# Altium schematic sheet RX Chain.SchDoc("RX Chain")
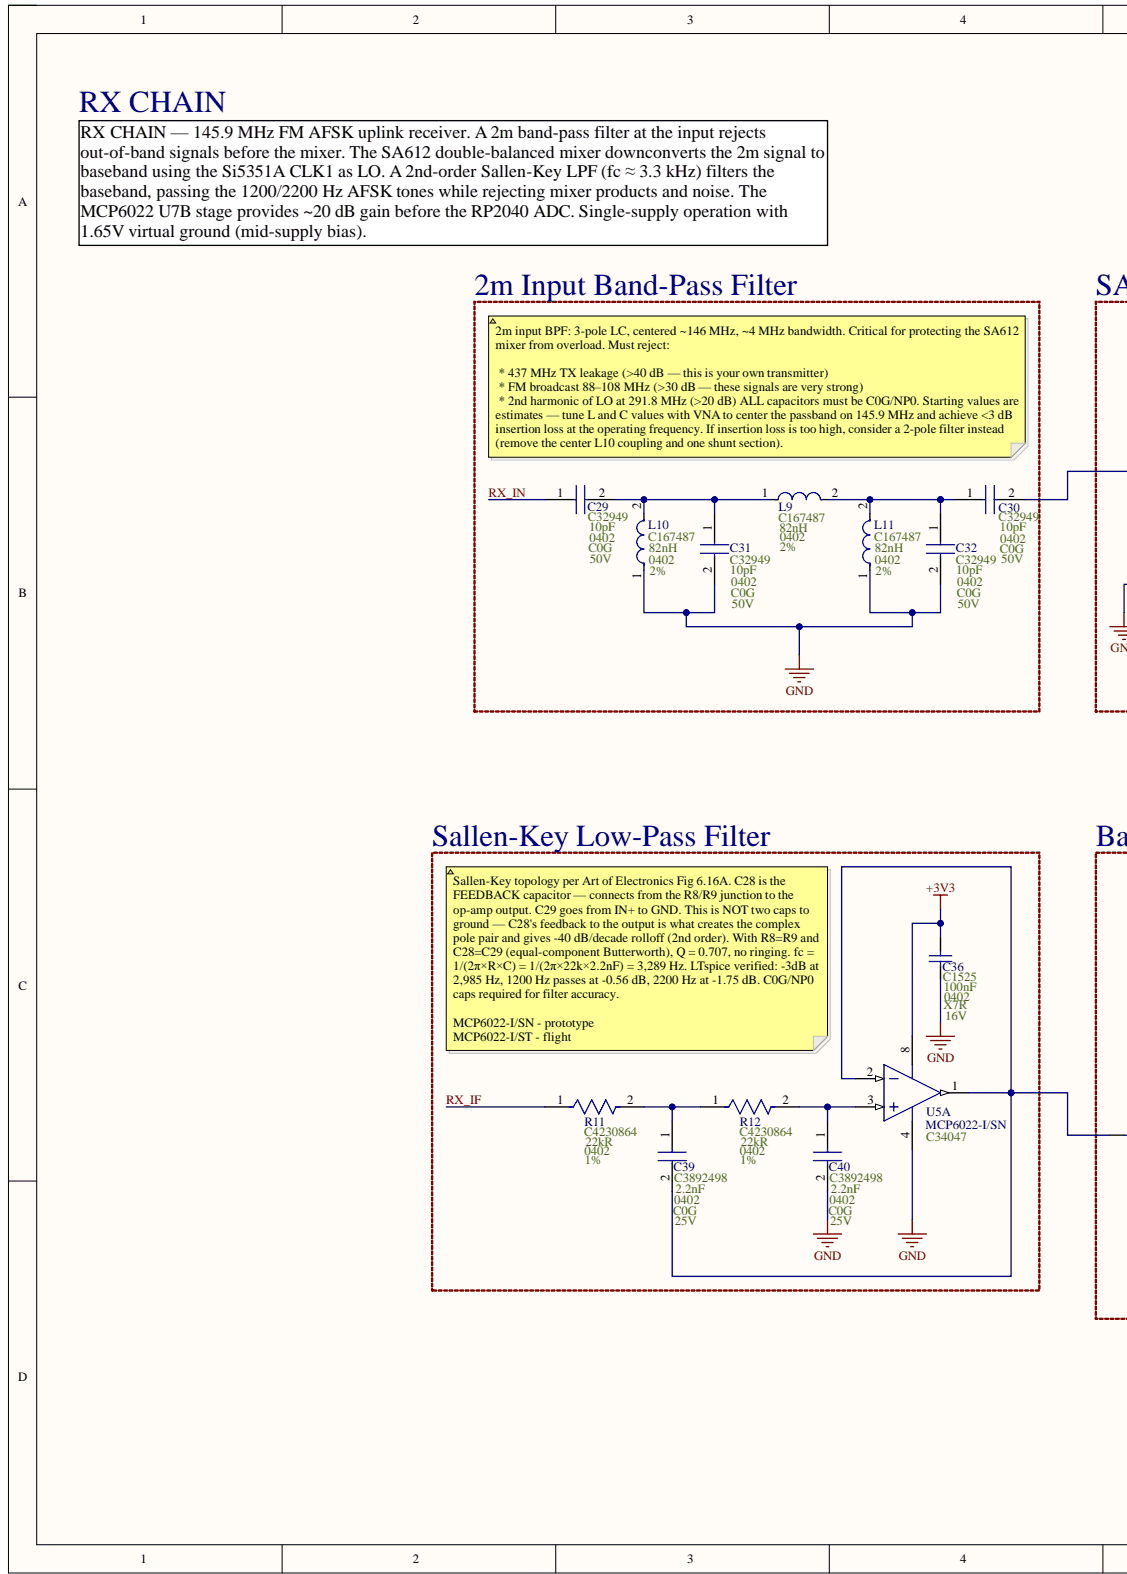
[diagram p0: RX Chain.SchDoc, left]
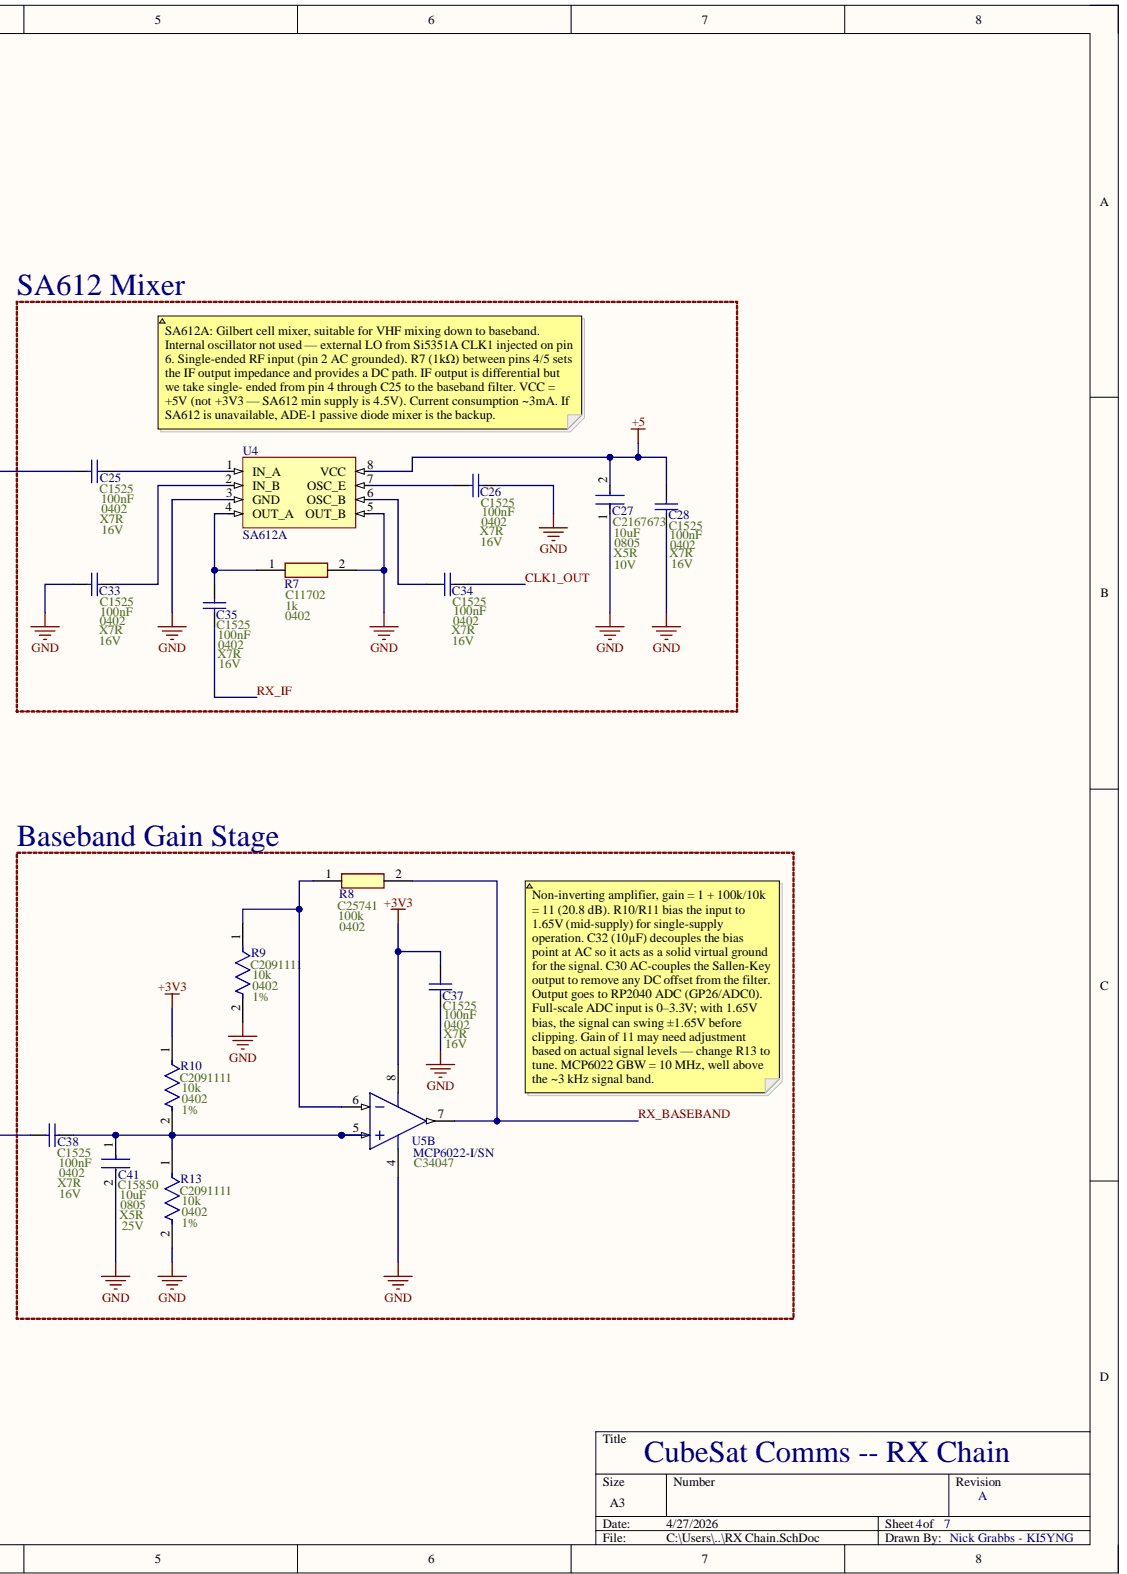
[diagram p1: RX Chain.SchDoc, right]

## Parts
C25  Capacitance: 100nF  Case Size/Dimension: 0402  Dielectric: X7R  LCSC Part #: C1525  [CAPC1050X55X23ML05T13]  (hidden comment: CL05B104KO5NNNC)
C26  Capacitance: 100nF  Case Size/Dimension: 0402  Dielectric: X7R  LCSC Part #: C1525  [CAPC1050X55X23ML05T13]  (hidden comment: CL05B104KO5NNNC)
C27  value 10uF  Capacitance: 10uF  LCSC Part #: C2167673  Package: 0805  [FP-C0805C-DG-MFG]  (hidden comment: C0805C106K8PACTU)
C28  Capacitance: 100nF  Case Size/Dimension: 0402  Dielectric: X7R  LCSC Part #: C1525  Rated Voltage: 16V  [CAPC1050X55X23ML05T13]  (hidden comment: CL05B104KO5NNNC)
C29  value 10pF  Case: 0402  Dielectric: C0G  LCSC Part #: C32949  [CAPC1005X55N]  (hidden comment: CL05C100JB5NNNC)
C30  value 10pF  Case: 0402  Dielectric: C0G  LCSC Part #: C32949  [CAPC1005X55N]  (hidden comment: CL05C100JB5NNNC)
C31  value 10pF  Case: 0402  Dielectric: C0G  LCSC Part #: C32949  Voltage: 50V  [CAPC1005X55N]  (hidden comment: CL05C100JB5NNNC)
C32  value 10pF  Case: 0402  Dielectric: C0G  LCSC Part #: C32949  Voltage: 50V  [CAPC1005X55N]  (hidden comment: CL05C100JB5NNNC)
C33  Capacitance: 100nF  Case Size/Dimension: 0402  Dielectric: X7R  LCSC Part #: C1525  Rated Voltage: 16V  [CAPC1050X55X23ML05T13]  (hidden comment: CL05B104KO5NNNC)
C34  Capacitance: 100nF  Case Size/Dimension: 0402  Dielectric: X7R  LCSC Part #: C1525  Rated Voltage: 16V  [CAPC1050X55X23ML05T13]  (hidden comment: CL05B104KO5NNNC)
C35  Capacitance: 100nF  Case Size/Dimension: 0402  Dielectric: X7R  LCSC Part #: C1525  Rated Voltage: 16V  [CAPC1050X55X23ML05T13]  (hidden comment: CL05B104KO5NNNC)
C36  Capacitance: 100nF  Case Size/Dimension: 0402  Dielectric: X7R  LCSC Part #: C1525  [CAPC1050X55X23ML05T13]  (hidden comment: CL05B104KO5NNNC)
C37  Capacitance: 100nF  Case Size/Dimension: 0402  Dielectric: X7R  LCSC Part #: C1525  Rated Voltage: 16V  [CAPC1050X55X23ML05T13]  (hidden comment: CL05B104KO5NNNC)
C38  Capacitance: 100nF  Case Size/Dimension: 0402  LCSC Part #: C1525  [CAPC1050X55X23ML05T13]  (hidden comment: CL05B104KO5NNNC)
C39  value 2.2nF  Case: 0402  Dielectric: C0G  LCSC Part #: C3892498  Voltage: 25V  [C0402]  (hidden comment: C0402C222F3GECAUTO)
C40  value 2.2nF  Case: 0402  Dielectric: C0G  LCSC Part #: C3892498  Voltage: 25V  [C0402]  (hidden comment: C0402C222F3GECAUTO)
C41  Capacitance: 10uF  Case Code (Imperial): 0805  LCSC Part #: C15850  [FP-CL21-IPC_A]  (hidden comment: CL21A106KAYNNNE)
L9  Case Code (Imperial): 0402  Inductance: 82nH  LCSC Part #: C167487  [FP-LQW15AN_00-W0_5-0_1-MFG]  (hidden comment: LQW15AN82NG00D)
L10  Case Code (Imperial): 0402  Inductance: 82nH  Inductance Tolerance: 2%  LCSC Part #: C167487  [FP-LQW15AN_00-W0_5-0_1-MFG]  (hidden comment: LQW15AN82NG00D)
L11  Case Code (Imperial): 0402  Inductance: 82nH  Inductance Tolerance: 2%  LCSC Part #: C167487  [FP-LQW15AN_00-W0_5-0_1-MFG]  (hidden comment: LQW15AN82NG00D)
R7  value 1k  Case: 0402  LCSC Part #: C11702  [RESC1005X40N]  (hidden comment: 0402WGF1001TCE)
R8  value 100k  Case: 0402  LCSC Part #: C25741  [RESC1005X40N]  (hidden comment: 0402WGF1003TCE)
R9  value 10k  Case: 0402  LCSC Part #: C2091111  Tolerance: 1%  [FP-CR0402-MFG]  (hidden comment: CR0402AFX-1002GAS)
R10  value 10k  Case: 0402  LCSC Part #: C2091111  Tolerance: 1%  [FP-CR0402-MFG]  (hidden comment: CR0402AFX-1002GAS)
R11  Case/Package: 0402  LCSC Part #: C4230864  Resistance: 22kR  [FP-AF0402-IPC_C]  (hidden comment: AF0402FR-0722KL)
R12  Case/Package: 0402  LCSC Part #: C4230864  Resistance: 22kR  [FP-AF0402-IPC_C]  (hidden comment: AF0402FR-0722KL)
R13  value 10k  Case: 0402  LCSC Part #: C2091111  Tolerance: 1%  [FP-CR0402-MFG]  (hidden comment: CR0402AFX-1002GAS)
U4  SA612A
U5  MCP6022-I/SN  LCSC Part #: C34047  [SOIC-SN8_N]  (parts A,B)

## Nets
+3V3: C36.1 C37.1 R10.1 U5.8
+5: C27.2 C28.1 U4.8(VCC)
GND: C26.1 C27.1 C28.2 C31.2 C32.2 C33.2 C36.2 C37.2 C40.2 C41.2 L10.1 L11.1 R7.2 R9.2 R13.2 U4.3(GND) U4.5(OUT_B) U5.4
CLK1_OUT: C34.1
RX_BASEBAND: R8.2 U5.7
RX_IF: C35.2 R11.1
RX_IN: C29.1
NetC25_1: C25.1 U4.1(IN_A)
NetC25_2: C25.2 C30.2
NetC26_2: C26.2 U4.7(OSC_E)
NetC29_2: C29.2 C31.1 L9.1 L10.2
NetC30_1: C30.1 C32.1 L9.2 L11.2
NetC33_1: C33.1 U4.2(IN_B)
NetC34_2: C34.2 U4.6(OSC_B)
NetC35_1: C35.1 R7.1 U4.4(OUT_A)
NetC38_1: C38.1 C41.1 R10.2 R13.1 U5.5
NetC38_2: C38.2 C39.2 U5.1 U5.2
NetC39_1: C39.1 R11.2 R12.1
NetC40_1: C40.1 R12.2 U5.3
NetR8_1: R8.1 R9.1 U5.6

## Library data of the parts
C25 C26 C36 = CMP-2000-05439-1: Chip Capacitor, 100 nF, +/- 10%, 16 V, -55 to 125 degC, 0402 (1005 Metric), RoHS, Tape and Reel; Manufacturer: Samsung Electro-Mechanics; Rated Voltage: 16V; Component Kind: Standard; Datasheet URL: https://datasheet.ciiva.com/29198/458718-da-01-en-vs-kondensator-0402-29198423.pdf; Datasheet Version: Nov-10; Library Name: Altium Content Vault; Manufacturer URL: http://www.samsungsem.com/global/index.jsp; Tolerance (%): ±10%
C27 = CMP-2007-03243-2: CAP CER 10UF 10V X5R 0805; 3DS Part Number: 220-00001; Manufacturer: Kemet; Material: Ceramic; Temperature Coefficient: 15%; Tolerance: 10%; Automotive: No; Category: Cap; Component Kind: Standard; ComponentLink2Description: Datasheet; ComponentLink2URL: https://content.kemet.com/datasheets/KEM_C1006_X5R_SMD.pdf; Device Class L1: Passive Components; Device Class L2: Capacitors; Device Class L3: Ceramic Capacitors; Digikey Description: CAP CER 10UF 10V X5R 0805; Height: 1.4mm; Ipc Land Pattern Name: CAPC200125X125; Lead Free: Yes; Library Name: Altium Content Vault; Manufacturer Part Number: C0805C106K8PACTU; Mouser Description: CAP CER 10UF 10V X5R 0805; Octopart: https://octopart.com/c0805c106k8pactu-kemet-98697; RoHS: TRUE; Temperature: -55°C to +85°C; Temperature Characteristic: X5R; Temperature Range High: +85°C; Temperature Range Low: -55°C; Voltage: 10V; Voltage Rating: 10V
C28 C33-C35 C37 = CMP-2000-05439-1: Chip Capacitor, 100 nF, +/- 10%, 16 V, -55 to 125 degC, 0402 (1005 Metric), RoHS, Tape and Reel; Manufacturer: Samsung Electro-Mechanics; Component Kind: Standard; Datasheet URL: https://datasheet.ciiva.com/29198/458718-da-01-en-vs-kondensator-0402-29198423.pdf; Datasheet Version: Nov-10; Library Name: Altium Content Vault; Manufacturer URL: http://www.samsungsem.com/global/index.jsp; Tolerance (%): ±10%
C29 C30 = CL05C100JB5NNNC: Capacitor; Manufacturer_Name: SAMSUNG; Voltage: 50V; Arrow Part Number: CL05C100JB5NNNC; Arrow Price/Stock: https://www.arrow.com/en/products/cl05c100jb5nnnc/samsung-electro-mechanics?region=nac; Component Kind: Standard; Datasheet Link: http://www.samsungsem.com/global/support/product-search/mlcc/1204759_4880.html; Height: 0.55mm; Manufacturer_Part_Number: CL05C100JB5NNNC; Mouser Part Number: 187-CL05C100JB5NNNC; Mouser Price/Stock: https://www.mouser.co.uk/ProductDetail/Samsung-Electro-Mechanics/CL05C100JB5NNNC?qs=349EhDEZ59pnfPPyAlzfQw%3D%3D
C31 C32 = CL05C100JB5NNNC: Capacitor; Manufacturer_Name: SAMSUNG; Arrow Part Number: CL05C100JB5NNNC; Arrow Price/Stock: https://www.arrow.com/en/products/cl05c100jb5nnnc/samsung-electro-mechanics?region=nac; Component Kind: Standard; Datasheet Link: http://www.samsungsem.com/global/support/product-search/mlcc/1204759_4880.html; Height: 0.55mm; Manufacturer_Part_Number: CL05C100JB5NNNC; Mouser Part Number: 187-CL05C100JB5NNNC; Mouser Price/Stock: https://www.mouser.co.uk/ProductDetail/Samsung-Electro-Mechanics/CL05C100JB5NNNC?qs=349EhDEZ59pnfPPyAlzfQw%3D%3D
C38 = CMP-2000-05439-1: Chip Capacitor, 100 nF, +/- 10%, 16 V, -55 to 125 degC, 0402 (1005 Metric), RoHS, Tape and Reel; Manufacturer: Samsung Electro-Mechanics; Dielectric: X7R; Rated Voltage: 16V; Component Kind: Standard; Datasheet URL: https://datasheet.ciiva.com/29198/458718-da-01-en-vs-kondensator-0402-29198423.pdf; Datasheet Version: Nov-10; Library Name: Altium Content Vault; Manufacturer URL: http://www.samsungsem.com/global/index.jsp; Tolerance (%): ±10%
C39 C40 = C0402C222F3GECAUTO: Capacitor; Manufacturer_Name: Yageo Group; Component Kind: Standard; Datasheet Link: https://content.kemet.com/datasheets/KEM_C1091_C0G_ESD.pdf; Height: 0.55mm; Manufacturer_Part_Number: C0402C222F3GECAUTO; Mouser Part Number: 80-C0402C222F3GECAUT; Mouser Price/Stock: https://www.mouser.com/Search/Refine.aspx?Keyword=80-C0402C222F3GECAUT
C41 = CMP-13271-002975-1: CL21 Series 0805 10uF 25V ±10% Tolerance X5R Multilayer Ceramic Chip Capacitor; Case Code (Metric): 2012; Case/Package: 0805; Component Kind: Standard; Depth: 1.25mm; Dielectric: X5R; Height: 1.25mm; Length: 2mm; Library Name: Altium Content Vault; Max Operating Temperature: 85°C; Min Operating Temperature: -55°C; Mount: Surface Mount; Package Quantity: 1; Packaging: Tape and Reel; Radiation Hardening: No; Thickness: 0.057inch; Tolerance: 10%; Voltage Rating: 25V; Width: 0.049inch
L9-L11 = CMP-06042-015778-1: Wire Wound RF Inductor 82nH ±2% 130mA 2.24Ω 0402 (1005); Core Material: Air; DC Resistance (DCR): 2.24R; Q Factor: 20; Self Resonant Frequency: 2.3GHz; Shielding: Unshielded; Test Frequency: 100MHz; Case Code (Metric): 1005; Case/Package: 0402; Component Kind: Standard; Current Rating: 130mA; DC Current: 130mA; Depth: 0.6mm; Height: 0.5mm; Lead Free: Lead Free; Length: 1mm; Library Name: Altium Content Vault; Max DC Current: 130mA; Max Operating Temperature: 125°C; Military Standard: Not; Min Operating Temperature: -55°C; Mount: Surface Mount; Number of Pins: 2; Package Quantity: 10000; Packaging: Tape and Reel; Radiation Hardening: No; REACH SVHC: No SVHC; Termination: SMD/SMT; Tolerance: 2%; Weight: 2.8E-05oz; Width: 0.02inch
  L9: Inductance Tolerance: 2%
R7 = 0402WGF1001TCE: Resistor; Manufacturer_Name: UNI-ROYAL(Uniroyal Elec); Component Kind: Standard; Datasheet Link: https://datasheet.lcsc.com/szlcsc/Uniroyal-Elec-0402WGF1001TCE_C11702.pdf; Height: 0.4mm; Manufacturer_Part_Number: 0402WGF1001TCE
R8 = 0402WGF1003TCE: Resistor; Manufacturer_Name: UNI-ROYAL(Uniroyal Elec); Component Kind: Standard; Datasheet Link: https://datasheet.lcsc.com/szlcsc/Uniroyal-Elec-0402WGF1003TCE_C25741.pdf; Height: 0.4mm; Manufacturer_Part_Number: 0402WGF1003TCE
R9 R10 R13 = CMP-07231-005993-1: Sulfur-Resistant, AEC-Q200 Compliant Chip Resistors 0402 10kΩ 0.063W 1% 100ppm/°C; Component Kind: Standard; Library Name: Altium Content Vault
R11 R12 = CMP-03412-041068-1: Anti-Sulfurated Chip Resistors Automotive Grade 22kΩ 1% 100ppm/°C 0402; Max Power Dissipation: 63mW; Component Kind: Standard; Features: Moisture Resistant; Height: 0.015inch; Length: 0.039inch; Library Name: Altium Content Vault; Max Operating Temperature: 155°C; Min Operating Temperature: -55°C; Number of Terminations: 2; Packaging: Tape and Reel; Resistor Type: Thick Film; RoHS Compliant: Yes; Series: AF; Temperature Coefficient: 100ppm/°C; Tolerance: 1%; Width: 0.02inch
U4 = CMP-031-00006-1: Component Kind: Standard; Library Name: Nick Grabbs
U5 = CMP-0187-00284-3: None; Amplifier Type: GENERAL PURPOSE; Bandwidth: 10MHz; Common Mode Rejection Ratio: 70dB; Gain: 110dB; Input Bias Current: 150pA; Input Offset Voltage (Vos): 500uV; Max Supply Voltage: 5.5V; Min Supply Voltage: 2.5V; Nominal Supply Current: 1mA; Number of Amplifiers: 2; Output Current: 22mA; Power Supply Rejection Ratio (PSRR): 74dB; Slew Rate: 7V/µs; Case/Package: SOIC; Component Kind: Standard; Gain Bandwidth Product: 10MHz; Harmonic Distortion: 0.00053%; Height: 0.049inch; Input Offset Voltage-Max: 500uV; Lead Free: Lead Free; Length: 0.192inch; Library Name: Altium Content Vault; Max Operating Temperature: 85°C; Min Operating Temperature: -40°C; Mount: Surface Mount; Number of Channels: 2; Number of Circuits: 2; Number of Elements: 2; Number of Pins: 8; Package Quantity: 100; Packaging: Rail/Tube; Radiation Hardening: No; REACH SVHC: No SVHC; RoHS Compliant: Yes; Supply Current: 1mA; Technology: CMOS; Unity Gain Bandwidth Product: 10MHz; Voltage Gain: 110dB; Width: 0.154inch
